# Altium schematic sheet LED Matrix-2.SchDoc("LED Matrix-2")
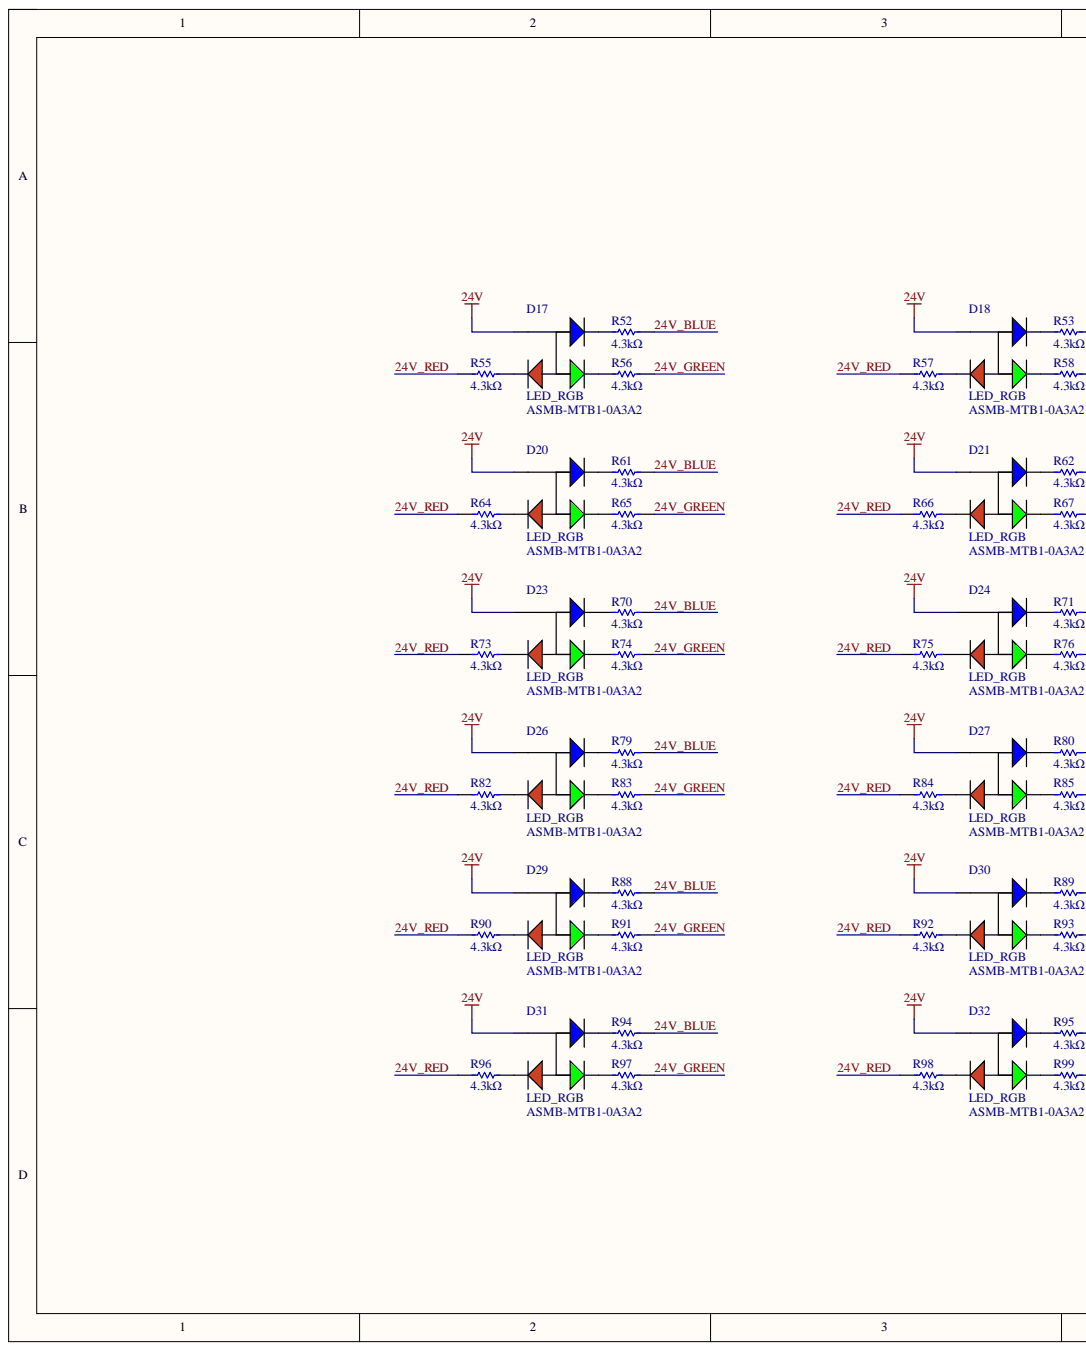
[diagram p0: LED Matrix-2.SchDoc, left]
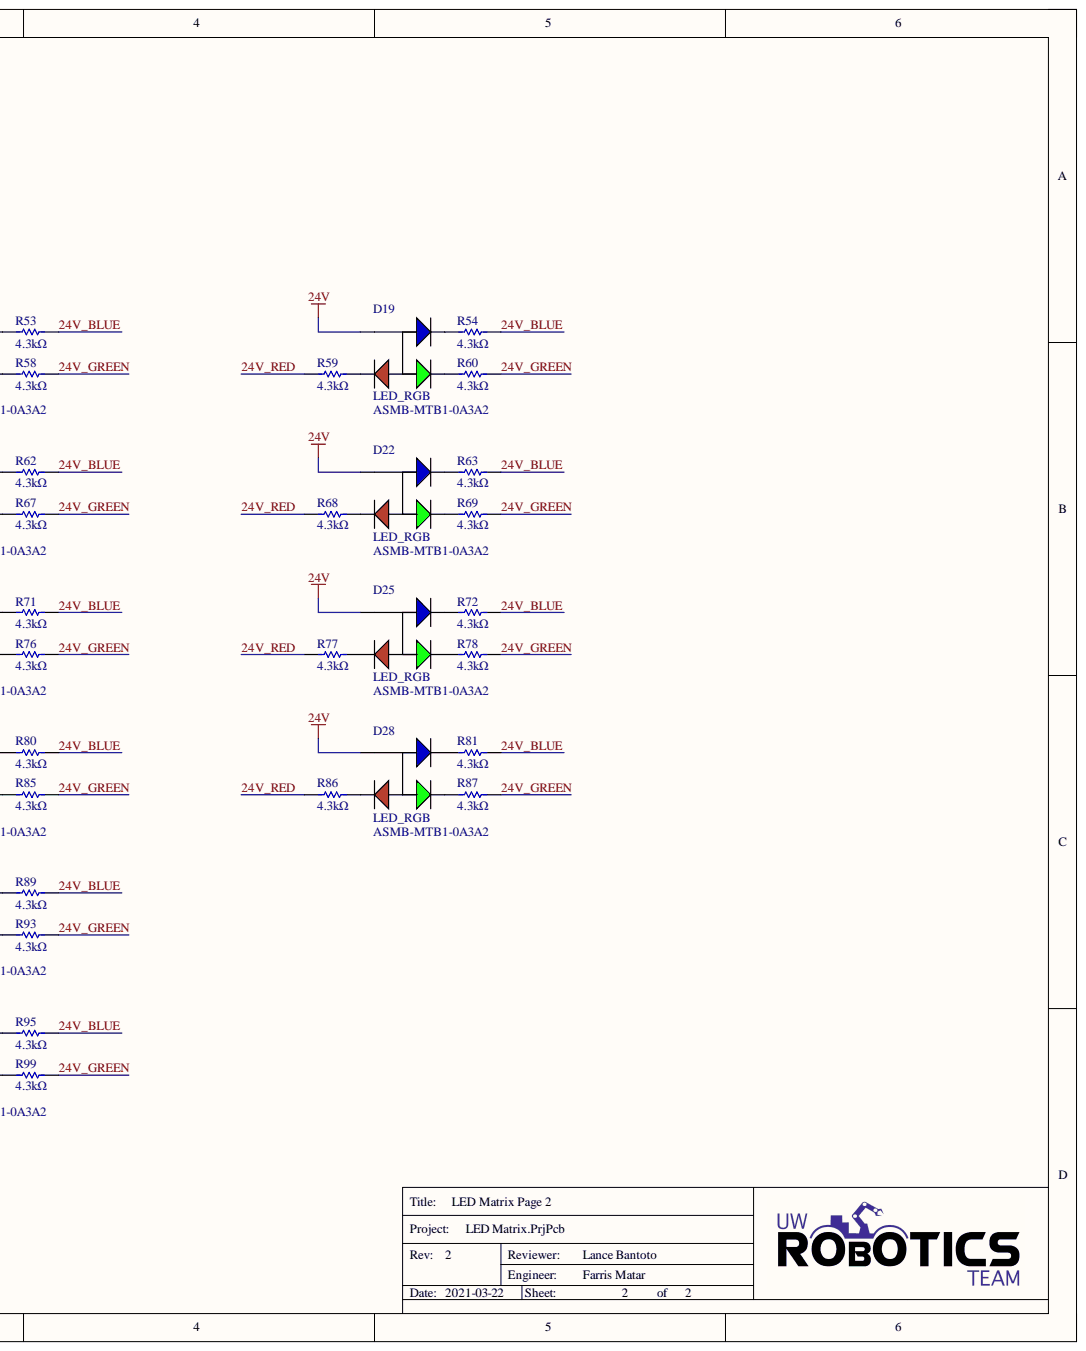
[diagram p1: LED Matrix-2.SchDoc, right]

## Parts
D17  LED_RGB  Manufacturer Part Number 1: ASMB-MTB1-0A3A2  [LED_RGB]
D18  LED_RGB  Manufacturer Part Number 1: ASMB-MTB1-0A3A2  [LED_RGB]
D19  LED_RGB  Manufacturer Part Number 1: ASMB-MTB1-0A3A2  [LED_RGB]
D20  LED_RGB  Manufacturer Part Number 1: ASMB-MTB1-0A3A2  [LED_RGB]
D21  LED_RGB  Manufacturer Part Number 1: ASMB-MTB1-0A3A2  [LED_RGB]
D22  LED_RGB  Manufacturer Part Number 1: ASMB-MTB1-0A3A2  [LED_RGB]
D23  LED_RGB  Manufacturer Part Number 1: ASMB-MTB1-0A3A2  [LED_RGB]
D24  LED_RGB  Manufacturer Part Number 1: ASMB-MTB1-0A3A2  [LED_RGB]
D25  LED_RGB  Manufacturer Part Number 1: ASMB-MTB1-0A3A2  [LED_RGB]
D26  LED_RGB  Manufacturer Part Number 1: ASMB-MTB1-0A3A2  [LED_RGB]
D27  LED_RGB  Manufacturer Part Number 1: ASMB-MTB1-0A3A2  [LED_RGB]
D28  LED_RGB  Manufacturer Part Number 1: ASMB-MTB1-0A3A2  [LED_RGB]
D29  LED_RGB  Manufacturer Part Number 1: ASMB-MTB1-0A3A2  [LED_RGB]
D30  LED_RGB  Manufacturer Part Number 1: ASMB-MTB1-0A3A2  [LED_RGB]
D31  LED_RGB  Manufacturer Part Number 1: ASMB-MTB1-0A3A2  [LED_RGB]
D32  LED_RGB  Manufacturer Part Number 1: ASMB-MTB1-0A3A2  [LED_RGB]
R52  Resistance: 4.3kΩ  [RESC1210]  (hidden comment: RES_4.3kΩ_1210_5%)
R53  Resistance: 4.3kΩ  [RESC1210]  (hidden comment: RES_4.3kΩ_1210_5%)
R54  Resistance: 4.3kΩ  [RESC1210]  (hidden comment: RES_4.3kΩ_1210_5%)
R55  Resistance: 4.3kΩ  [RESC1210]  (hidden comment: RES_4.3kΩ_1210_5%)
R56  Resistance: 4.3kΩ  [RESC1210]  (hidden comment: RES_4.3kΩ_1210_5%)
R57  Resistance: 4.3kΩ  [RESC1210]  (hidden comment: RES_4.3kΩ_1210_5%)
R58  Resistance: 4.3kΩ  [RESC1210]  (hidden comment: RES_4.3kΩ_1210_5%)
R59  Resistance: 4.3kΩ  [RESC1210]  (hidden comment: RES_4.3kΩ_1210_5%)
R60  Resistance: 4.3kΩ  [RESC1210]  (hidden comment: RES_4.3kΩ_1210_5%)
R61  Resistance: 4.3kΩ  [RESC1210]  (hidden comment: RES_4.3kΩ_1210_5%)
R62  Resistance: 4.3kΩ  [RESC1210]  (hidden comment: RES_4.3kΩ_1210_5%)
R63  Resistance: 4.3kΩ  [RESC1210]  (hidden comment: RES_4.3kΩ_1210_5%)
R64  Resistance: 4.3kΩ  [RESC1210]  (hidden comment: RES_4.3kΩ_1210_5%)
R65  Resistance: 4.3kΩ  [RESC1210]  (hidden comment: RES_4.3kΩ_1210_5%)
R66  Resistance: 4.3kΩ  [RESC1210]  (hidden comment: RES_4.3kΩ_1210_5%)
R67  Resistance: 4.3kΩ  [RESC1210]  (hidden comment: RES_4.3kΩ_1210_5%)
R68  Resistance: 4.3kΩ  [RESC1210]  (hidden comment: RES_4.3kΩ_1210_5%)
R69  Resistance: 4.3kΩ  [RESC1210]  (hidden comment: RES_4.3kΩ_1210_5%)
R70  Resistance: 4.3kΩ  [RESC1210]  (hidden comment: RES_4.3kΩ_1210_5%)
R71  Resistance: 4.3kΩ  [RESC1210]  (hidden comment: RES_4.3kΩ_1210_5%)
R72  Resistance: 4.3kΩ  [RESC1210]  (hidden comment: RES_4.3kΩ_1210_5%)
R73  Resistance: 4.3kΩ  [RESC1210]  (hidden comment: RES_4.3kΩ_1210_5%)
R74  Resistance: 4.3kΩ  [RESC1210]  (hidden comment: RES_4.3kΩ_1210_5%)
R75  Resistance: 4.3kΩ  [RESC1210]  (hidden comment: RES_4.3kΩ_1210_5%)
R76  Resistance: 4.3kΩ  [RESC1210]  (hidden comment: RES_4.3kΩ_1210_5%)
R77  Resistance: 4.3kΩ  [RESC1210]  (hidden comment: RES_4.3kΩ_1210_5%)
R78  Resistance: 4.3kΩ  [RESC1210]  (hidden comment: RES_4.3kΩ_1210_5%)
R79  Resistance: 4.3kΩ  [RESC1210]  (hidden comment: RES_4.3kΩ_1210_5%)
R80  Resistance: 4.3kΩ  [RESC1210]  (hidden comment: RES_4.3kΩ_1210_5%)
R81  Resistance: 4.3kΩ  [RESC1210]  (hidden comment: RES_4.3kΩ_1210_5%)
R82  Resistance: 4.3kΩ  [RESC1210]  (hidden comment: RES_4.3kΩ_1210_5%)
R83  Resistance: 4.3kΩ  [RESC1210]  (hidden comment: RES_4.3kΩ_1210_5%)
R84  Resistance: 4.3kΩ  [RESC1210]  (hidden comment: RES_4.3kΩ_1210_5%)
R85  Resistance: 4.3kΩ  [RESC1210]  (hidden comment: RES_4.3kΩ_1210_5%)
R86  Resistance: 4.3kΩ  [RESC1210]  (hidden comment: RES_4.3kΩ_1210_5%)
R87  Resistance: 4.3kΩ  [RESC1210]  (hidden comment: RES_4.3kΩ_1210_5%)
R88  Resistance: 4.3kΩ  [RESC1210]  (hidden comment: RES_4.3kΩ_1210_5%)
R89  Resistance: 4.3kΩ  [RESC1210]  (hidden comment: RES_4.3kΩ_1210_5%)
R90  Resistance: 4.3kΩ  [RESC1210]  (hidden comment: RES_4.3kΩ_1210_5%)
R91  Resistance: 4.3kΩ  [RESC1210]  (hidden comment: RES_4.3kΩ_1210_5%)
R92  Resistance: 4.3kΩ  [RESC1210]  (hidden comment: RES_4.3kΩ_1210_5%)
R93  Resistance: 4.3kΩ  [RESC1210]  (hidden comment: RES_4.3kΩ_1210_5%)
R94  Resistance: 4.3kΩ  [RESC1210]  (hidden comment: RES_4.3kΩ_1210_5%)
R95  Resistance: 4.3kΩ  [RESC1210]  (hidden comment: RES_4.3kΩ_1210_5%)
R96  Resistance: 4.3kΩ  [RESC1210]  (hidden comment: RES_4.3kΩ_1210_5%)
R97  Resistance: 4.3kΩ  [RESC1210]  (hidden comment: RES_4.3kΩ_1210_5%)
R98  Resistance: 4.3kΩ  [RESC1210]  (hidden comment: RES_4.3kΩ_1210_5%)
R99  Resistance: 4.3kΩ  [RESC1210]  (hidden comment: RES_4.3kΩ_1210_5%)

## Nets
24V: D17.4 D18.4 D19.4 D20.4 D21.4 D22.4 D23.4 D24.4 D25.4 D26.4 D27.4 D28.4 D29.4 D30.4 D31.4 D32.4
24V_BLUE: R52.2 R53.2 R54.2 R61.2 R62.2 R63.2 R70.2 R71.2 R72.2 R79.2 R80.2 R81.2 R88.2 R89.2 R94.2 R95.2
24V_GREEN: R56.2 R58.2 R60.2 R65.2 R67.2 R69.2 R74.2 R76.2 R78.2 R83.2 R85.2 R87.2 R91.2 R93.2 R97.2 R99.2
24V_RED: R55.1 R57.1 R59.1 R64.1 R66.1 R68.1 R73.1 R75.1 R77.1 R82.1 R84.1 R86.1 R90.1 R92.1 R96.1 R98.1
NetD17_1: D17.1 R55.2
NetD17_2: D17.2 R56.1
NetD17_3: D17.3 R52.1
NetD18_1: D18.1 R57.2
NetD18_2: D18.2 R58.1
NetD18_3: D18.3 R53.1
NetD19_1: D19.1 R59.2
NetD19_2: D19.2 R60.1
NetD19_3: D19.3 R54.1
NetD20_1: D20.1 R64.2
NetD20_2: D20.2 R65.1
NetD20_3: D20.3 R61.1
NetD21_1: D21.1 R66.2
NetD21_2: D21.2 R67.1
NetD21_3: D21.3 R62.1
NetD22_1: D22.1 R68.2
NetD22_2: D22.2 R69.1
NetD22_3: D22.3 R63.1
NetD23_1: D23.1 R73.2
NetD23_2: D23.2 R74.1
NetD23_3: D23.3 R70.1
NetD24_1: D24.1 R75.2
NetD24_2: D24.2 R76.1
NetD24_3: D24.3 R71.1
NetD25_1: D25.1 R77.2
NetD25_2: D25.2 R78.1
NetD25_3: D25.3 R72.1
NetD26_1: D26.1 R82.2
NetD26_2: D26.2 R83.1
NetD26_3: D26.3 R79.1
NetD27_1: D27.1 R84.2
NetD27_2: D27.2 R85.1
NetD27_3: D27.3 R80.1
NetD28_1: D28.1 R86.2
NetD28_2: D28.2 R87.1
NetD28_3: D28.3 R81.1
NetD29_1: D29.1 R90.2
NetD29_2: D29.2 R91.1
NetD29_3: D29.3 R88.1
NetD30_1: D30.1 R92.2
NetD30_2: D30.2 R93.1
NetD30_3: D30.3 R89.1
NetD31_1: D31.1 R96.2
NetD31_2: D31.2 R97.1
NetD31_3: D31.3 R94.1
NetD32_1: D32.1 R98.2
NetD32_2: D32.2 R99.1
NetD32_3: D32.3 R95.1

## Library data of the parts
D17-D32 = LED_RGB: LED RGB DIFFUSED 4PLCC SMD; Manufacturer 1: Broadcom; Color: Blue,Green,Red; Dominant Wavelength: 470nm; Forward Voltage: 3.1V; Lens Color: White; Lens Style: Round; Luminous Intensity: 350mcd; Package/Footprint: 4-PLCC; Test Current: 20mA; Viewing Angle: 115°; Case/Package: PLCC; Component Kind: Standard; Height: 1.9mm; Length: 3.2mm; Lens Transparency: Diffused; Mounting Type: SMD; Number of Pins: 4; Supplier 1: Digi-Key; Supplier Part Number 1: 516-3280-1-ND; Width: 2.8mm; Library Name: UWRT_Schematic_Library_2020.SchLib
R52-R99 = RES_4.3kΩ_1210_5%: RES SMD 4.3K OHM 5% 1/2W 1210; Manufacturer 1: Panasonic; Manufacturer Part Number 1: ERJ-14YJ432U; Power Rating: 500mW; Voltage Rating: 200V; Component Kind: Standard; Mount: Surface Mount; Package: 1210; Supplier 1: Digi-Key; Supplier Part Number 1: P4.3KVCT-ND; Tolerance: 5%; Library Name: UWRT_Schematic_Library_2020.SchLib
